# Altium schematic sheet AnalogIO.SchDoc
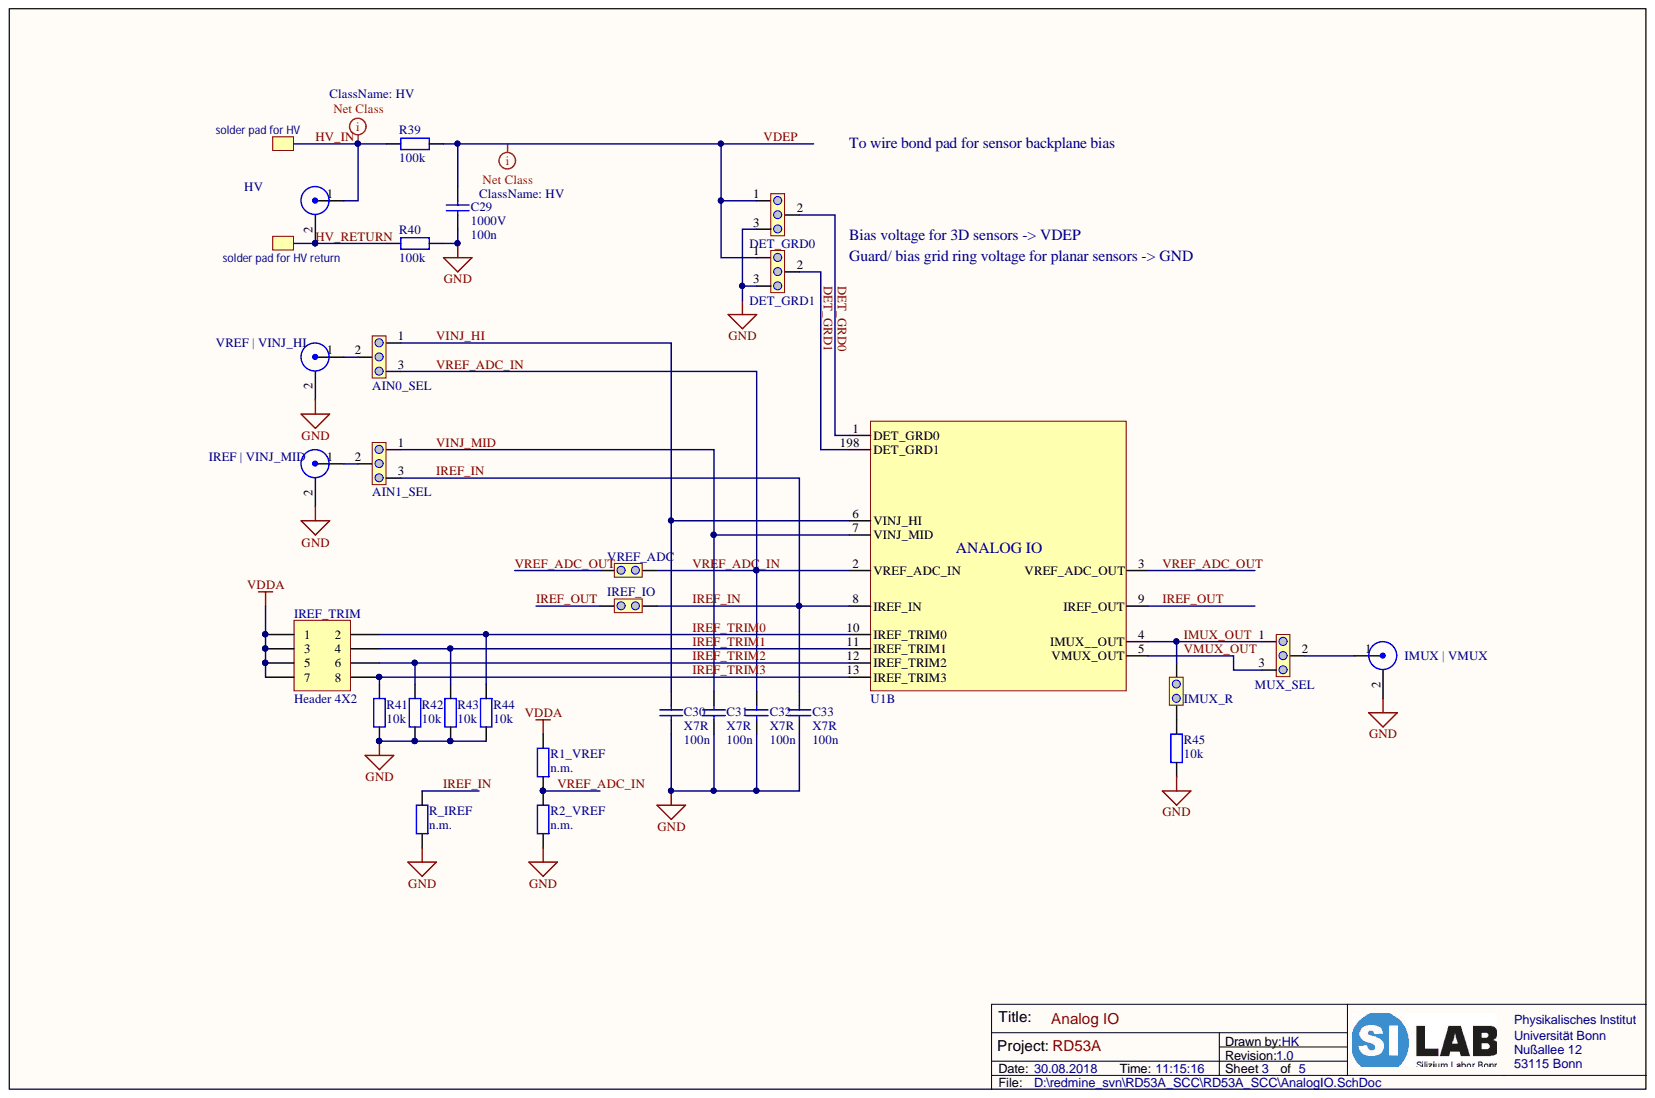
[diagram p0: AnalogIO.SchDoc]

## Parts
AIN0_SEL  -  [HDR 1x3]  (value not drawn)
AIN1_SEL  -  [HDR 1x3]  (value not drawn)
C29  1000V  value 100n  [C5750_met_L]
C30  X7R  value 100n  [C0402_imp_L]
C31  X7R  value 100n  [C0402_imp_L]
C32  X7R  value 100n  [C0402_imp_L]
C33  X7R  value 100n  [C0402_imp_L]
DET_GRD0  -  [HDR 1x3]  (value not drawn)
DET_GRD1  -  [HDR 1x3]  (value not drawn)
HV  -  [LEMO horiz.]  (value not drawn)
IMUX_|_VMUX  -  [LEMO horiz.]  (value not drawn)
IMUX_R  -  [CON 1x2 std]  (value not drawn)
IREF_|_VINJ_MID  -  [LEMO horiz.]  (value not drawn)
IREF_IO  -  [CON 1x2 std]  (value not drawn)
IREF_TRIM  Header 4X2  [HDR2X4]
MUX_SEL  -  [HDR 1x3]  (value not drawn)
R1_VREF  R  value n.m.  [R0402_imp_N]
R2_VREF  R  value n.m.  [R0402_imp_N]
R39  R?  value 100k  [R1206_imp_N]
R40  R?  value 100k  [R1206_imp_N]
R41  R  value 10k  [R0402_imp_N]
R42  R  value 10k  [R0402_imp_N]
R43  R  value 10k  [R0402_imp_N]
R44  R  value 10k  [R0402_imp_N]
R45  R  value 10k  [R0402_imp_N]
R_IREF  R  value n.m.  [R0402_imp_N]
U1  RD53A  [RD53A Chip]  (value not drawn)  (part B)
VREF_|_VINJ_HI  -  [LEMO horiz.]  (value not drawn)
VREF_ADC  -  [CON 1x2 std]  (value not drawn)

## Nets
GND: C29.2 C30.2 C31.2 C32.2 C33.2 DET_GRD0.3 DET_GRD1.3 IMUX_|_VMUX.2 IREF_|_VINJ_MID.2 R2_VREF.1 R40.2 R41.2 R42.2 R43.2 R44.2 R45.1 R_IREF.1 VREF_|_VINJ_HI.2
VDDA: IREF_TRIM.1 IREF_TRIM.3 IREF_TRIM.5 IREF_TRIM.7 R1_VREF.2
DET_GRD0: DET_GRD0.2 U1.1(DET_GRD0)
DET_GRD1: DET_GRD1.2 U1.198(DET_GRD1)
HV_IN: HV.1 R39.1
HV_RETURN: HV.2 R40.1
IMUX_OUT: IMUX_R.1 MUX_SEL.1 U1.4(IMUX__OUT)
IREF_IN: AIN1_SEL.3 C33.1 IREF_IO.1 R_IREF.2 U1.8(IREF_IN)
IREF_OUT: IREF_IO.2 U1.9(IREF_OUT)
IREF_TRIM0: IREF_TRIM.2 R44.1 U1.10(IREF_TRIM0)
IREF_TRIM1: IREF_TRIM.4 R43.1 U1.11(IREF_TRIM1)
IREF_TRIM2: IREF_TRIM.6 R42.1 U1.12(IREF_TRIM2)
IREF_TRIM3: IREF_TRIM.8 R41.1 U1.13(IREF_TRIM3)
VDEP: C29.1 DET_GRD0.1 DET_GRD1.1 R39.2
VINJ_HI: AIN0_SEL.1 C30.1 U1.6(VINJ_HI)
VINJ_MID: AIN1_SEL.1 C31.1 U1.7(VINJ_MID)
VMUX_OUT: MUX_SEL.3 U1.5(VMUX_OUT)
VREF_ADC_IN: AIN0_SEL.3 C32.1 R1_VREF.1 R2_VREF.2 U1.2(VREF_ADC_IN) VREF_ADC.1
VREF_ADC_OUT: U1.3(VREF_ADC_OUT) VREF_ADC.2
NetAIN0_SEL_2: AIN0_SEL.2 VREF_|_VINJ_HI.1
NetAIN1_SEL_2: AIN1_SEL.2 IREF_|_VINJ_MID.1
NetIMUX | VMUX_1: IMUX_|_VMUX.1 MUX_SEL.2
NetIMUX_R_2: IMUX_R.2 R45.2

## Library data of the parts
AIN0_SEL AIN1_SEL DET_GRD0 DET_GRD1 MUX_SEL = JUMPER 1X3S: Jumper, Solderbridge 1x3; Class: Connector; Comment_1: -; Component Kind: Standard; Constraints: -; HelpURL: -; LatestRevisionDate: 24-Sep-2008; PackageDescription: -; Type_1: -; Value: -; Library Name: Silab_Lib.IntLib; Publisher: Silab C.H.
C29-C33 = C: Capacitor; Class: Passive; Constraints: 6.3V; Type_1: SMD Chip Capacitor; Comment_1: -; Component Kind: Standard; HelpURL: -; LatestRevisionDate: 27-Nov-2007; PackageDescription: -; Signal Integrity: Capacitor; Simulation: Capacitor; Library Name: Silab_Lib.IntLib; Publisher: Silab J.S.
HV IMUX_|_VMUX IREF_|_VINJ_MID VREF_|_VINJ_HI = LEMO coax: LEMO/BNC Buchse, aufrecht od. gewinkelt; Class: Connector; Comment_1: LEMO; Type_1: EPL.00.250.NTN; Component Kind: Standard; Constraints: -; HelpURL: -; LatestRevisionDate: 24-Sep-2008; PackageDescription: -; Value: -; Library Name: Silab_Lib.IntLib; Publisher: Silab C.H.
IMUX_R IREF_IO VREF_ADC = JUMPER 1X2S: Jumper, Solderbridge 1x2; Class: Connector; Comment_1: -; Component Kind: Standard; Constraints: -; HelpURL: -; LatestRevisionDate: 24-Sep-2008; PackageDescription: -; Type_1: -; Value: -; Library Name: Silab_Lib.IntLib; Publisher: Silab C.H.
IREF_TRIM = Header 4X2: Header, 4-Pin, Dual row; Component Kind: Standard; LatestRevisionDate: 17-Jul-2002; LatestRevisionNote: Re-released for DXP Platform.; Library Name: Miscellaneous Connectors.IntLib; Signal Integrity: Connector; Publisher: Altium Limited
R1_VREF R2_VREF R39-R45 R_IREF = R: SMD Chip Resistor; Class: Passive; Constraints: 1%; Comment_1: -; Component Kind: Standard; HelpURL: -; LatestRevisionDate: 27-Nov-2007; PackageDescription: -; Signal Integrity: Resistor; Simulation: Resistor; Type_1: SMD Chip Resistor; Library Name: Silab_Lib.IntLib; Publisher: Silab J.S.
U1 = RD53A: Component Kind: Standard; Description: RD53A; Library Name: RD53A.SchLib
